# Altium schematic sheet Main Hull Power Board.SchDoc("Main Hull Power Board") (continued)

## Library data of the parts
C58-C61 C66-C68 = CMP-123-000002-5: MAL214699906E3. Vishay Extreme 100uF 100 V Aluminium Electrolytic Capacitor 1216, 146 CTI Series 1500  6000h 12.5 x 12.5 x 16mm; Geometry.Height: 16.5mm; Component Kind: Standard; Datasheet Link: https://www.vishay.com/docs/28403/146cti.pdf; Design Item ID: MAL214699906E3; Manufacturer_Name: Vishay; Manufacturer_Part_Number: MAL214699906E3; Mouser Part Number: 594-MAL214699906E3; Mouser Price/Stock: https://www.mouser.co.uk/ProductDetail/Vishay-BC-Components/MAL214699906E3?qs=gUBFJjLdUib9zXP5zbfSLg%3D%3D; Source: MAL214699906E3.SchLib; Library Name: BumbleBee Autonomous Systems - NUS
C62-C65 C73 C74 = CMP-123-000003-3: AEH0608100M050R. Aluminum Electrolytic Capacitors - SMD 50V 10uF 5000Hrs 20%; Manufacturer_Name: KYOCERA; Geometry.Height: 8.5mm; Component Kind: Standard; Design Item ID: AEH0608100M050R; Manufacturer_Part_Number: AEH0608100M050R; Source: AEH0608100M050R.SchLib; Library Name: BumbleBee Autonomous Systems - NUS
C69 C70 = CMP-05427-000837-1: ECA1JHG102B. Aluminum Electrolytic Capacitors (Radial Lead Type) AEC-Q200 Qualified 1000uF ±20% 63V; Capacitance: 1mF; Lead Diameter: 0.8mm; Lead/Base Style: Straight; Life (Hours): 2000Hour; Ripple Current: 930mA; Case/Package: Radial; Component Kind: Standard; Contact Plating: Tin; Diameter: 16mm; Height: 25mm; Height - Seated (Max): 1.063inch; Lead Pitch: 7.5mm; Length: 25mm; Library Name: Altium Content Vault; Max Operating Temperature: 105°C; Min Operating Temperature: -55°C; Mount: Through Hole; Package Quantity: 250; Packaging: Tape and Reel; Radiation Hardening: No; RoHS Compliant: Yes; Series: NHG; Termination: Radial; Tolerance: 20%; Voltage Rating: 63V; Voltage Rating (DC): 63V
C71 C72 = CMP-05427-001340-1: ECA1JM471. Aluminum Electrolytic Capacitors (Radial Lead Type) 470uF ±20% 63V; Dielectric Material: Aluminium; Dissipation Factor: 0.11%; Lead Diameter: 0.6mm; Lead/Base Style: Straight; Leakage Current: 3uA; Life (Hours): 2000Hour; Polarity: Polar; Ripple Current: 750mA; Capacitance: 470uF; Case/Package: Radial; Component Kind: Standard; Construction: RADIAL; Contact Plating: Tin; Diameter: 12.5mm; Height: 20mm; Height - Seated (Max): 0.866inch; Lead Free: Lead Free; Lead Pitch: 5mm; Length: 20mm; Library Name: Altium Content Vault; Max Operating Temperature: 85°C; Min Operating Temperature: -40°C; Mount: Through Hole; Package Quantity: 200; Packaging: Bulk; Radiation Hardening: No; REACH SVHC: No SVHC; RoHS Compliant: Yes; Series: M; Termination: Radial; Tolerance: 20%; Voltage: 63V; Voltage Rating: 63V; Voltage Rating (DC): 63V
C75 C78-C83 = CMP-123-000000-2: C0603C104K1RACAUTO. SMD Auto X7R, Ceramic, 0.1 uF, 10%, 100 VDC, X7R, SMD, MLCC, Temperature Stable, Automotive Grade, 0603; Manufacturer_Name: KEMET; Geometry.Height: 0.95mm; Component Kind: Standard; Datasheet Link: https://connect.kemet.com:7667/gateway/IntelliData-ComponentDocumentation/1.0/download/specsheet/C0603C104K1RACAUTO; Design Item ID: C0603C104K1RACAUTO; Manufacturer_Part_Number: C0603C104K1RACAUTO; Mouser Part Number: 80-C0603C104K1RAUTO; Mouser Price/Stock: https://www.mouser.co.uk/ProductDetail/KEMET/C0603C104K1RACAUTO?qs=3Rah4i%252BhyCGTQ5uPNXtfZA%3D%3D; Source: C0603C104K1RACAUTO.SchLib; Library Name: BumbleBee Autonomous Systems - NUS
C76 = CMP-08246-000430-1: CGA5L1X7R1H106K160AE. Cap Ceramic 10uF 50V X7R 10% Pad SMD 1206 +125°C Automotive T/R; Component Kind: Standard; Library Name: Altium Content Vault
C77 = CMP-2006-01162-1: VJ0603Y103JXBAC. Multilayer Ceramic Capacitors MLCC - SMD/SMT .01UF 100volts X7R 5%; Manufacturer: Vitramon; Component Kind: Standard; Library Name: Altium Content Vault; Part Number: VJ0603Y103JXBAC
D7-D15 D21 D22 = CMP-00010-2: Led Red Diffused 0603 SMD; Manufacturer Part No.: 150060RS55040; Dominant Wavelength: 624nm; Forward Voltage: 2V; Lens Size: 0.8mm; Lens Style: Flat; Luminous Intensity: 90mcd; Test Current: 20mA; Viewing Angle: 140°; Case Code (Metric): 1608; Case/Package: 0603; Component Kind: Standard; Datasheet: https://www.farnell.com/datasheets/2621644.pdf?src-supplier=Farnell; Height: 0.5mm; Length: 1.6mm; Lens Transparency: Diffused; Mounting Technology: Surface Mount; Packaging: Cut Tape; RoHS Compliant: Yes; Series: WL-SMDC; Width: 0.8mm; Library Name: BumbleBee Autonomous Systems - NUS
D16 D19 D31-D34 = CMP-00012-1: Led, Blue, 470 Nm, 3.2 V, 30 Ma, 145 Mcd Rohs Compliant: Yes; Manufacturer Part No.: 150060BS75000; Dominant Wavelength: 470nm; Forward Current: 30mA; Forward Voltage: 3.2V; Lens Color: Colorless; Lens Size: 0.7mm; Lens Style: Clear,Flat,Rectangular; Lens Transparency: Clear; Luminous Intensity: 145mcd; Test Current: 20mA; Viewing Angle: 140°; Case Code (Metric): 1608; Case/Package: 0603; Component Kind: Standard; Height: 0.8mm; Length: 1.6mm; Max Operating Temperature: 85°C; Min Operating Temperature: -40°C; Mounting Technology: Surface Mount; Package Quantity: 1; Packaging: Cut Tape; Reach SVHC Compliant: Unknown; RoHS Compliant: Yes; Series: WL-SMCW; Wavelength: 470nm; Width: 0.8mm; Library Name: BumbleBee Autonomous Systems - NUS
D17 D18 D20 = CMP-07163-000040-1: DIODE GEN PURP 75V 150MA SOD323F; Element Configuration: Single; Forward Voltage: 1V; Max Forward Surge Current (Ifsm): 2A; Peak Reverse Current: 5uA; Power Dissipation: 200mW; Recovery Time: 4ns; Average Rectified Current: 150mA; Component Kind: Standard; Contact Plating: Tin; Forward Current: 150mA; Height: 1mm; Lead Free: Lead Free; Length: 1.8mm; Library Name: Altium Content Vault; Max Operating Temperature: 150°C; Max Repetitive Reverse Voltage (Vrrm): 75V; Max Reverse Voltage (DC): 75V; Min Operating Temperature: -55°C; Mount: Surface Mount; Number of Pins: 2; Package Quantity: 1; Packaging: Tape and Reel; Radiation Hardening: No; REACH SVHC: No SVHC; Reverse Recovery Time: 4ns; Reverse Voltage: 75V; Weight: 0.0276g; Width: 1.35mm
D23-D30 = CMP-00011-1: Led, Green, 570 Nm, 2 V, 30 Ma, 40 Mcd Rohs Compliant: Yes; Manufacturer Part No.: 150060VS75000; Dominant Wavelength: 570nm; Forward Current: 20mA; Forward Voltage: 2V; Lens Color: Colorless; Lens Size: 0.7mm; Lens Style: Rectangular,Flat; Lens Transparency: Clear; Luminous Intensity: 40mcd; Power Rating: 72mW; Reverse Voltage (DC): 5V; Viewing Angle: 140°; Case Code (Metric): 1608; Case/Package: 0603; Component Kind: Standard; Height: 0.8mm; Illumination Color: Green; Length: 1.6mm; Max Operating Temperature: 85°C; Min Operating Temperature: -40°C; Mounting Technology: Surface Mount; Package Quantity: 4000; Packaging: Cut Tape; Pins: 2; RoHS Compliant: Yes; Series: WL-SMCW; Test Current: 20mA; Weight: 0.000882oz; Width: 0.8mm; Library Name: BumbleBee Autonomous Systems - NUS
F1 F4 = CMP-2000-05461-1: FUSE HOLDER, PCB MOUNT, 500VAC, 20A, ROHS; Manufacturer: Keystone-Electronics; Current Rating: 30A; For Use With/Related Products: ATC,ATO257,MINI897; Fuse Size: 0.43"Lx0.15"Wx0.64"H(10.92mmx3.81mmx16.25mm); Fuse Type: Blade; Fuseholder Type: Block; Number of Circuits: 1; Voltage: 500V; Category: CircuitProtection; Component Kind: Standard; Contact Finish: TinNickel; Contact Material: Brass; Datasheet URL: http://datasheet.octopart.com/3557-2-Keystone-datasheet-15715937.pdf; Datasheet Version: Apr-13; Family: Fuseholders; Library Name: Altium Content Vault; Manufacturer URL: http://www.keyelco.com/; Mounting Type: PCB; Orientation: Vertical; Series: -; Termination Style: PCPin
F2 F3 F5-F9 = CMP-08605-000035-1: FUSE BRD MNT 7A 125VAC/VDC 2SMD; Manufacturer: Littelfuse Inc; Fuse Blow Speed: Fast; Max Fuse Ac Voltage: 125V; Automotive: No; Category: Fuse; Component Kind: Standard; ComponentLink2Description: Datasheet; ComponentLink2URL: https://www.littelfuse.com/~/media/electronics/datasheets/fuses/littelfuse_fuse_154_154t_154l_154tl_datasheet.pdf.pdf; Device Class L1: Circuit Protection; Device Class L2: Fuses; Device Class L3: unset; Digikey Description: FUSE BRD MNT 7A 125VAC/VDC 2SMD; Fuse Current: 7A; Height: 3.81mm; Lead Free: Yes; Library Name: Altium Content Vault; Manufacturer Part Number: 0154007.DR; Max Fuse Dc Voltage: 125V; Mouser Description: Surface Mount Fuses Fuseblock w/ fuse 7A OMNI BLOK 154; Octopart: https://octopart.com/0154007.dr-littelfuse-565863; Package: 0154; RoHS: TRUE; Temperature: -55°C to +125°C; Temperature Range High: +125°C; Temperature Range Low: -55°C
FL1 = CMP-171-000000-4: KEMET, SCF-XV, AC Line Filters, Common Mode, 1.2 mH; Geometry.Height: 26.5mm; Component Kind: Standard; Datasheet Link: https://connect.kemet.com:7667/gateway/IntelliData-ComponentDocumentation/1.0/download/specsheet/SCF25XV-220-1R9A007JH; Design Item ID: SCF25XV-220-1R9A007JH; Manufacturer_Name: KEMET; Manufacturer_Part_Number: SCF25XV-220-1R9A007JH; Source: SCF25XV-220-1R9A007JH.SchLib; Library Name: BumbleBee Autonomous Systems - NUS
FL2 = CMP-222-000000-2: Common Mode Chokes / Filters 1000Volts 0.22mH 35A ESR=0.7mOhms AECQ2; Manufacturer_Name: KEMET; Geometry.Height: 27.5mm; Component Kind: Standard; Datasheet Link: https://connect.kemet.com:7667/gateway/IntelliData-ComponentDocumentation/1.0/download/datasheet/SCF25XV-350-2R4A003JH.pdf; Design Item ID: SCF25XV-350-2R4A003JH; Manufacturer_Part_Number: SCF25XV-350-2R4A003JH; Mouser Part Number: 80-SCF25XV3502R403JH; Mouser Price/Stock: https://www.mouser.co.uk/ProductDetail/KEMET/SCF25XV-350-2R4A003JH?qs=MyNHzdoqoQKmMjHMH8qqgA%3D%3D; Source: SCF25XV-350-2R4A003JH.SchLib; Library Name: BumbleBee Autonomous Systems - NUS
IC1 = MC74HCT595ADTR2G: Integrated Circuit; Manufacturer_Name: onsemi; Arrow Part Number: MC74HCT595ADTR2G; Arrow Price/Stock: https://www.arrow.com/en/products/mc74hct595adtr2g/on-semiconductor?region=nac; Component Kind: Standard; Datasheet Link: http://uk.rs-online.com/web/p/products/1869674; Height: 1.2mm; Manufacturer_Part_Number: MC74HCT595ADTR2G; Mouser Part Number: 863-MC74HCT595ADTR2G; Mouser Price/Stock: https://www.mouser.co.uk/ProductDetail/onsemi/MC74HCT595ADTR2G?qs=BJFa2WV4K9nrOp4%2Fz2VmwA%3D%3D
IC2-IC5 = TLV9030QDBVRQ1: Integrated Circuit; Manufacturer_Name: Texas Instruments; Component Kind: Standard; Datasheet Link: https://www.ti.com/lit/ds/symlink/tlv9030-q1.pdf?HQS=dis-mous-null-mousermode-dsf-pf-null-wwe&ts=1701232014608&ref_url=https%253A%252F%252Fwww.mouser.tw%252F; Height: 1.45mm; Library Name: SamacSys.SchLib; Manufacturer_Part_Number: TLV9030QDBVRQ1; Mouser Part Number: 595-TLV9030QDBVRQ1; Mouser Price/Stock: https://www.mouser.co.uk/ProductDetail/Texas-Instruments/TLV9030QDBVRQ1?qs=ST9lo4GX8V1gn8%2F5siaZUA%3D%3D
J4 J10 = CMP-141-000008-5: Component Kind: Standard; ComponentLink1Description: Mech Drawing; ComponentLink1URL: https://components101.com/connectors/xt90-battery-connector; Description: XT-90 Vertical; Library Name: BumbleBee Autonomous Systems - NUS
J5 J6 J8 J9 J12 J13 = 43045-0224: Connector; Manufacturer_Name: Molex; Component Kind: Standard; Datasheet Link: https://www.molex.com/pdm_docs/sd/430450224_sd.pdf; Height: 9.91mm; Manufacturer_Part_Number: 43045-0224; Mouser Part Number: 538-43045-0224; Mouser Price/Stock: https://www.mouser.co.uk/ProductDetail/Molex/43045-0224?qs=R7%2FZKp6KZ2Zab9wRYagBDA%3D%3D
J7 = CMP-02766-001776-1: CONN HEADER VERT 4POS 2.54MM; Gender: Male; Number of Contacts: 4; Component Kind: Standard; Contact Material: Bronze; Contact Plating: Gold; Library Name: Altium Content Vault; Mount: Through Hole; Number of Rows: 1; Packaging: Bulk; Pitch: 2.54mm; Radiation Hardening: No; Reach SVHC Compliant: Yes; RoHS Compliant: Yes; Series: HTSW; Termination: Through Hole
J11 = CMP-062-000000-1: Connector; Manufacturer_Name: SAMTEC; Component Kind: Standard; Datasheet Link: https://suddendocs.samtec.com/prints/t2m-1xx-xx-x-d-xx-xx-x-xx-mkt.pdf?_gl=1*1h8jfhh*_ga*ODgwNjE2ODg0LjE2NDkxNjE4OTQ.*_ga_3KFNZC07WW*MTY4MDE1NTk5OS4xMjYuMC4xNjgwMTU2MDg2LjYwLjAuMA..; Design Item ID: T2M-102-01-L-D-SM-WT; Height: 6.68mm; Manufacturer_Part_Number: T2M-102-01-L-D-SM-WT; Mouser Part Number: 200-T2M10201LDSMWT; Mouser Price/Stock: https://www.mouser.co.uk/ProductDetail/Samtec/T2M-102-01-L-D-SM-WT?qs=PB6%2FjmICvI1RhUpc5sXmcA%3D%3D; Source: SamacSys.SchLib; Library Name: BumbleBee Autonomous Systems - NUS
J14 J16 J29 = CMP-062-000001-2: Connector; Manufacturer_Name: SAMTEC; Arrow Part Number: T2M-104-01-L-D-SM-WT; Arrow Price/Stock: https://www.arrow.com/en/products/t2m-104-01-l-d-sm-wt/samtec; Component Kind: Standard; Datasheet Link: http://suddendocs.samtec.com/prints/t2m-1xx-xx-x-d-xx-xx-mkt.pdf; Design Item ID: T2M-104-01-L-D-SM-WT; Manufacturer_Part_Number: T2M-104-01-L-D-SM-WT; Mouser Part Number: 200-T2M10401LDSMWT; Mouser Price/Stock: https://www.mouser.com/Search/Refine.aspx?Keyword=200-T2M10401LDSMWT; Source: SamacSys.SchLib; Library Name: BumbleBee Autonomous Systems - NUS
J15 = CMP-141-000000-2: Conn Power HDR 12 POS 4.2mm Solder ST Thru-Hole 12 Terminal 1 Port Mini-Fit Jr Bag; MF: {'manufacturer': u'Molex'}; Availability: Unavailable; Component Kind: Standard; Design Item ID: 39288120; MP: 39288120; Package: None; Price: None; Source: 39-28-8120.SchLib; Library Name: BumbleBee Autonomous Systems - NUS
J17 J18 = 43650-0218: Connector; Manufacturer_Name: Molex; Component Kind: Standard; Datasheet Link: https://www.molex.com/pdm_docs/sd/436500218_sd.pdf; Height: 9.9mm; Manufacturer_Part_Number: 43650-0218; Mouser Part Number: 538-43650-0218; Mouser Price/Stock: https://www.mouser.co.uk/ProductDetail/Molex/43650-0218?qs=z57USrEQgt0ffE4b1Ju68Q%3D%3D
J19-J28 = 39-28-8020: Connector; Manufacturer_Name: Molex; Component Kind: Standard; Datasheet Link: https://www.molex.com/pdm_docs/sd/039281023_sd.pdf; Height: 12.8mm; Manufacturer_Part_Number: 39-28-8020; Mouser Part Number: 538-39-28-8020; Mouser Price/Stock: https://www.mouser.co.uk/ProductDetail/Molex/39-28-8020?qs=ZZBj1wiNDbnzWLcHr%2Fqwqw%3D%3D
L7 L10 = CMP-126-000002-2: Ind,23.5x22x12.6mm,10uH+/-20%,33A,shd; Manufacturer_Name: Bourns; Geometry.Height: 13mm; Component Kind: Standard; Datasheet Link: https://www.bourns.com/docs/Product-Datasheets/SRP2313CC.pdf; Design Item ID: SRP2313CC-100M; Manufacturer_Part_Number: SRP2313CC-100M; Source: SRP2313CC-100M.SchLib; Library Name: BumbleBee Autonomous Systems - NUS
L8 L9 L11 = CMP-126-000003-2: SRR1260-100M. Fixed Inductors 10uH 20% SMD 1260; Manufacturer_Name: Bourns; Geometry.Height: 6.5mm; Component Kind: Standard; Datasheet Link: https://www.arrow.com/en/products/srr1260-100m/bourns?region=europe; Design Item ID: SRR1260-100M; Manufacturer_Part_Number: SRR1260-100M; Mouser Part Number: 652-SRR1260-100M; Mouser Price/Stock: https://www.mouser.co.uk/ProductDetail/Bourns/SRR1260-100M?qs=%2FPiZ59IM4y0arRCchlmf7Q%3D%3D; Source: SRR1260-100M.SchLib; Library Name: BumbleBee Autonomous Systems - NUS
LS1 = CMI-1210-5-95T: Loudspeaker or Buzzer; Manufacturer_Name: CUI Devices; Component Kind: Standard; Datasheet Link: https://www.cuidevices.com/product/resource/cmi-1210-5-95t.pdf; Height: 10mm; Manufacturer_Part_Number: CMI-1210-5-95T; Mouser Part Number: 179-CMI-1210-5-95T; Mouser Price/Stock: https://www.mouser.co.uk/ProductDetail/CUI-Devices/CMI-1210-5-95T?qs=Rp5uXu7WBW9WJf9tZv97Vg%3D%3D
P1-P3 P6-P8 = CMP-1502-01062-3: NexarPartId: 32855521; Connector Type: Male Pin; Contact Resistance: 20mΩ; Current Rating: 3A; Gender: Male; Number of Contacts: 3; Operating Supply Voltage: 250V; Pitch: 2.54mm; Color: Black; Component Kind: Standard; Contact Material: Copper; Contact Mating Length: 5.9944mm; Contact Plating: Gold; Height: 8.94mm; Lead Free: Lead Free; Lead Pitch: 2.54mm; Library Name: Altium Content Vault; Max Operating Temperature: 105°C; Min Operating Temperature: -40°C; Mount: Through Hole; Number of Pins: 3; Number of Positions: 3; Number of Rows: 1; Orientation: Vertical; Packaging: Bulk; REACH SVHC: Unknown; RoHS: Compliant; Termination: Solder; Voltage Rating: 250V
P4 P5 P9 = CMP-1712-00002-6: NexarPartId: 20081794; Connector Type: Male Pin; Contact Resistance: 20mΩ; Current Rating: 3A; Gender: Male; Number of Contacts: 2; Operating Supply Voltage: 250V; Pitch: 2.54mm; Color: Black; Component Kind: Standard; Contact Gender: Male; Contact Material: Copper; Contact Mating Length: 5.9944mm; Contact Plating: Gold; Height: 8.94mm; Housing Material: PBT; Lead Free: Lead Free; Lead Pitch: 2.54mm; Library Name: Altium Content Vault; Max Operating Temperature: 125°C; Min Operating Temperature: -40°C; Mount: Through Hole; Number of Pins: 2; Number of Positions: 2; Number of Rows: 1; Orientation: Vertical; Packaging: Bulk; REACH SVHC: No SVHC; RoHS: Compliant; Termination: Through Hole; Voltage Rating: 250V
Q1-Q3 Q5 = CMP-2000-04934-1: N-Channel Logic Level Enhancement Mode Field Effect Transistor, 1.7 V, -55 to 150 degC, 3-Pin SOT-23, RoHS, Tape and Reel; Manufacturer: Fairchild; Channel Type: N; Maximum Continuous Drain Current: 0.17A; Maximum Drain Source Voltage: 100V; Maximum Gate Source Voltage: ±20V; Package Version: Rev. 12, 03/2016; Rad Hard: No; RDS-on: 6000@10VmOhm; Typical Fall Time: 2.4ns; Typical Rise Time: 9ns; Typical Turn-Off Delay Time: 17ns; Typical Turn-On Delay Time: 1.7ns; Category: MOSFET; Channel Mode: Enhancement; Component Kind: Standard; Datasheet URL: https://www.fairchildsemi.com/datasheets/BS/BSS123.pdf; Datasheet Version: Jun-03; Library Name: Altium Content Vault; Manufacturer URL: https://www.fairchildsemi.com/; Mounting: SurfaceMount; Operating Temperature: -55to150degC; Package: 3SOT-23; Package Description: 3-Pin Small Outline Transistor, Body 2.92 x 1.325 mm, Pitch 0.95 mm; Package Reference: SOT23-3; Package URL: http://www.fairchildsemi.com/dwg/MA/MA03D.pdf; Packaging: Tape&Reel
Q4 = CMP-12801-000039-1: MOSFET P-CH 100V 0.27A SOT23; Manufacturer: Diodes Inc.; Automotive Grade: Grade 0; Continuous Drain Current: -0.27A; Depletion Mode: False; Drain To Source Breakdown Voltage: -100V; Drain To Source Resistance: 2.8Ω; Gate Charge At Vgs: 1.8nC @ -10V; Gate To Source Voltage: 20V; Input Capacitace At Vds: 87pF @ -25V; Max Forward Diode Voltage: -1.3V; Max Junction Temp: +150°C; Number Of Channels: 1; Power Dissipation: 0.38W; Pulse Drain Current: -1A; Reverse Recovery Charge: 24.8nC; Reverse Recovery Time: 17.8ns; Rthja Max: 333°C/W; Standoff Height: 0.013mm; Threshold Vgs Max: -3V; Threshold Vgs Min: -1V; Turn Off Delay Time: 8.4ns; Turn On Delay Time: 3.3ns; Typ Forward Diode Voltage: -0.82V; Automotive: Yes; Category: Trans; Component Kind: Standard; ComponentLink2Description: Datasheet; ComponentLink2URL: https://www.diodes.com/assets/Datasheets/DMP10H4D2S.pdf; Device Class L1: Discrete Semiconductors; Device Class L2: Transistors; Device Class L3: MOSFETs; Digikey Description: MOSFET P-CH 100V 0.27A SOT23; Drain To Source Voltage: -100V; Footprint Url: https://www.diodes.com/assets/Package-Files/SOT23.pdf; Height: 1.1mm; Ipc Land Pattern Name: SOT92P240X102-3; Lead Free: Yes; Library Name: Altium Content Vault; Manufacturer Part Number: DMP10H4D2S-7; Mouser Description: Trans MOSFET P-CH 100V 0.27A Automotive 3-Pin SOT-23 T/R; Number Of P Channels: 1; Octopart: https://octopart.com/dmp10h4d2s-7-diodes+inc.-66661026; Package: SOT23; RoHS: TRUE; Temperature: -55°C to +150°C; Temperature Range High: +150°C; Temperature Range Low: -55°C
R23 R24 R26-R31 R34 R37 R38 R41 R44 R45 R47 R50 R52 R53 R58 R62 R65 R72 R80 R81 = CMP-05422-000107-1: ERJ-PB3B1002V. RES SMD 10K OHM 0.1% 1/5W 0603; Max Power Dissipation: 200mW; Resistance: 10kR; Temperature Coefficient: 50ppm/°C; Case Code (Imperial): 0603; Case Code (Metric): 1608; Case/Package: 0603; Component Kind: Standard; Features: Automotive AEC-Q200; Height - Seated (Max): 0.022inch; Length: 0.063inch; Library Name: Altium Content Vault; Max Operating Temperature: 155°C; Min Operating Temperature: -55°C; Number of Terminations: 2; Packaging: Tape and Reel; Resistor Type: Thick Film; Tolerance: 0.1%; Width: 0.032inch
R25 R35 R36 R43 R48 R54 R61 R73 = CMP-147-000011-1: ERJ-UP3J391V. Res Thick Film 0603 390 Ohm 5% 0.25W(1/4W) ±200ppm/C Sulfur Resistant Pad SMD Automotive T/R; Component Kind: Standard; Library Name: BumbleBee Autonomous Systems - NUS
R32 R33 R42 R59 R60 = CMP-00053-1: RES SMD 0 OHM JUMPER 1/10W 0603; Max Power Dissipation: 100mW; Resistance Tolerance: 5%; Temperature Coefficient: 200ppm/°C; Tolerance: 1%; Value: 0mR; Voltage Rating: 50V; Case Code (Metric): 1608; Case/Package: 0603; Component Kind: Standard; Construction: Rectangular; Contact Plating: Tin,Matte; Depth: 0.8mm; Features: Moisture Resistant; Height: 0.45mm; Lead Free: Lead Free; Length: 1.6mm; Max Operating Temperature: 155°C; Military Standard: Not; Min Operating Temperature: -55°C; Number of Terminations: 2; Package Quantity: 5000; Packaging: Tape and Reel; Pins: 2; Power Rating: 100mW; Reach SVHC Compliant: Unknown; Resistor Type: Thick Film; RoHS Compliant: Yes; Series: RC; Technology: THICK FILM; Termination: SMD/SMT; Voltage Rating (DC): 50V; Width: 0.8mm; Library Name: BumbleBee Autonomous Systems - NUS
R39 R40 R46 R51 R56 R63 R64 R67-R71 R74-R79 = CMP-1659-00009-5: RC0603FR-071KL. RES 1K OHM 1% 1/10W 0603; Max Power Dissipation: 100mW; Resistance: 1kR; Temperature Coefficient: 100ppm/°C; Voltage Rating: 50V; Case Code (Imperial): 0603; Case Code (Metric): 1608; Case/Package: 0603; Component Kind: Standard; Construction: Rectangular; Contact Plating: Tin; Depth: 0.8mm; Features: Moisture Resistant; Height: 0.45mm; Lead Free: Lead Free; Length: 1.6mm; Library Name: Altium Content Vault; Max Operating Temperature: 155°C; Military Standard: Not; Min Operating Temperature: -55°C; Number of Terminations: 2; Packaging: Tape and Reel; Power Rating: 100mW; Radiation Hardening: No; REACH SVHC: No SVHC; Resistance Tolerance: 1%; Resistor Type: Thick Film; RoHS Compliant: Yes; Series: RC; Size Code: 0603; Technology: THICK FILM; Termination: SMD/SMT; Tolerance: 1%
R49 R55 R57 = CMP-05422-000114-1: ERJ-PB3B1003V. RES SMD 100K OHM 0.1% 1/5W 0603; Max Power Dissipation: 200mW; Resistance: 100kR; Temperature Coefficient: 50ppm/°C; Case Code (Imperial): 0603; Case Code (Metric): 1608; Case/Package: 0603; Component Kind: Standard; Features: Automotive AEC-Q200; Height - Seated (Max): 0.022inch; Length: 0.063inch; Library Name: Altium Content Vault; Max Operating Temperature: 155°C; Min Operating Temperature: -55°C; Number of Terminations: 2; Packaging: Tape and Reel; Resistor Type: Thick Film; Tolerance: 0.1%; Width: 0.032inch
R66 = CMP-2000-00336-1: ERJ3EKF2201V. Thick Film Resistors - SMD 0603 2.2Kohms 1% AEC-Q200; Manufacturer: Panasonic; Component Kind: Standard; Library Name: Altium Content Vault; Part Number: ERJ3EKF2201V
TP1-TP18 = CMP-2000-05181-2: PC TEST POINT MINIATURE BLACK; Body Material: Bronze; Hole Diameter: 1.6mm; Inside Diameter: 1.27mm; Insulation: Insulated; Number of Contacts: 1; Outside Diameter: 2.54mm; Plating: Silver; Color: Black; Component Kind: Standard; Contact Material: Bronze; Contact Plating: Silver; Diameter: 2.54mm; Flammability Rating: UL94 V-0; Height: 0.3inch; Housing Color: Black; Insulation Material: Nylon; Lead Free: Lead Free; Length: 7.62mm; Library Name: Altium Content Vault; Material: Nylon; Mount: Through Hole; Number of Ports: 1; Orientation: Straight; Package Quantity: 100; Packaging: Bulk; REACH SVHC: No SVHC; RoHS Compliant: Yes; Series: THM; Termination: Through Hole
U5-U8 = CMP-191-000000-2: Transistor Output Optocouplers Transistor Coupler Automotive; AEC-Q101; Manufacturer_Name: Toshiba; Manufacturer_Part_Number: TLX9291A(GBTPL,F; Geometry.Height: 2.3mm; Component Kind: Standard; Datasheet Link: https://toshiba.semicon-storage.com/info/docget.jsp?did=53137&prodName=TLX9291A; Design Item ID: TLX9291A_GBTPL_F; Mouser Part Number: 757-TLX9291AGBTPLF; Mouser Price/Stock: https://www.mouser.co.uk/ProductDetail/Toshiba/TLX9291AGBTPLF?qs=YwPsRIUVAOcOvZScDNZO1w%3D%3D; Source: TLX9291A_GBTPL_F.SchLib; Library Name: BumbleBee Autonomous Systems - NUS
